# Altium schematic sheet K210_WiFi.SchDoc(K210_WiFi)
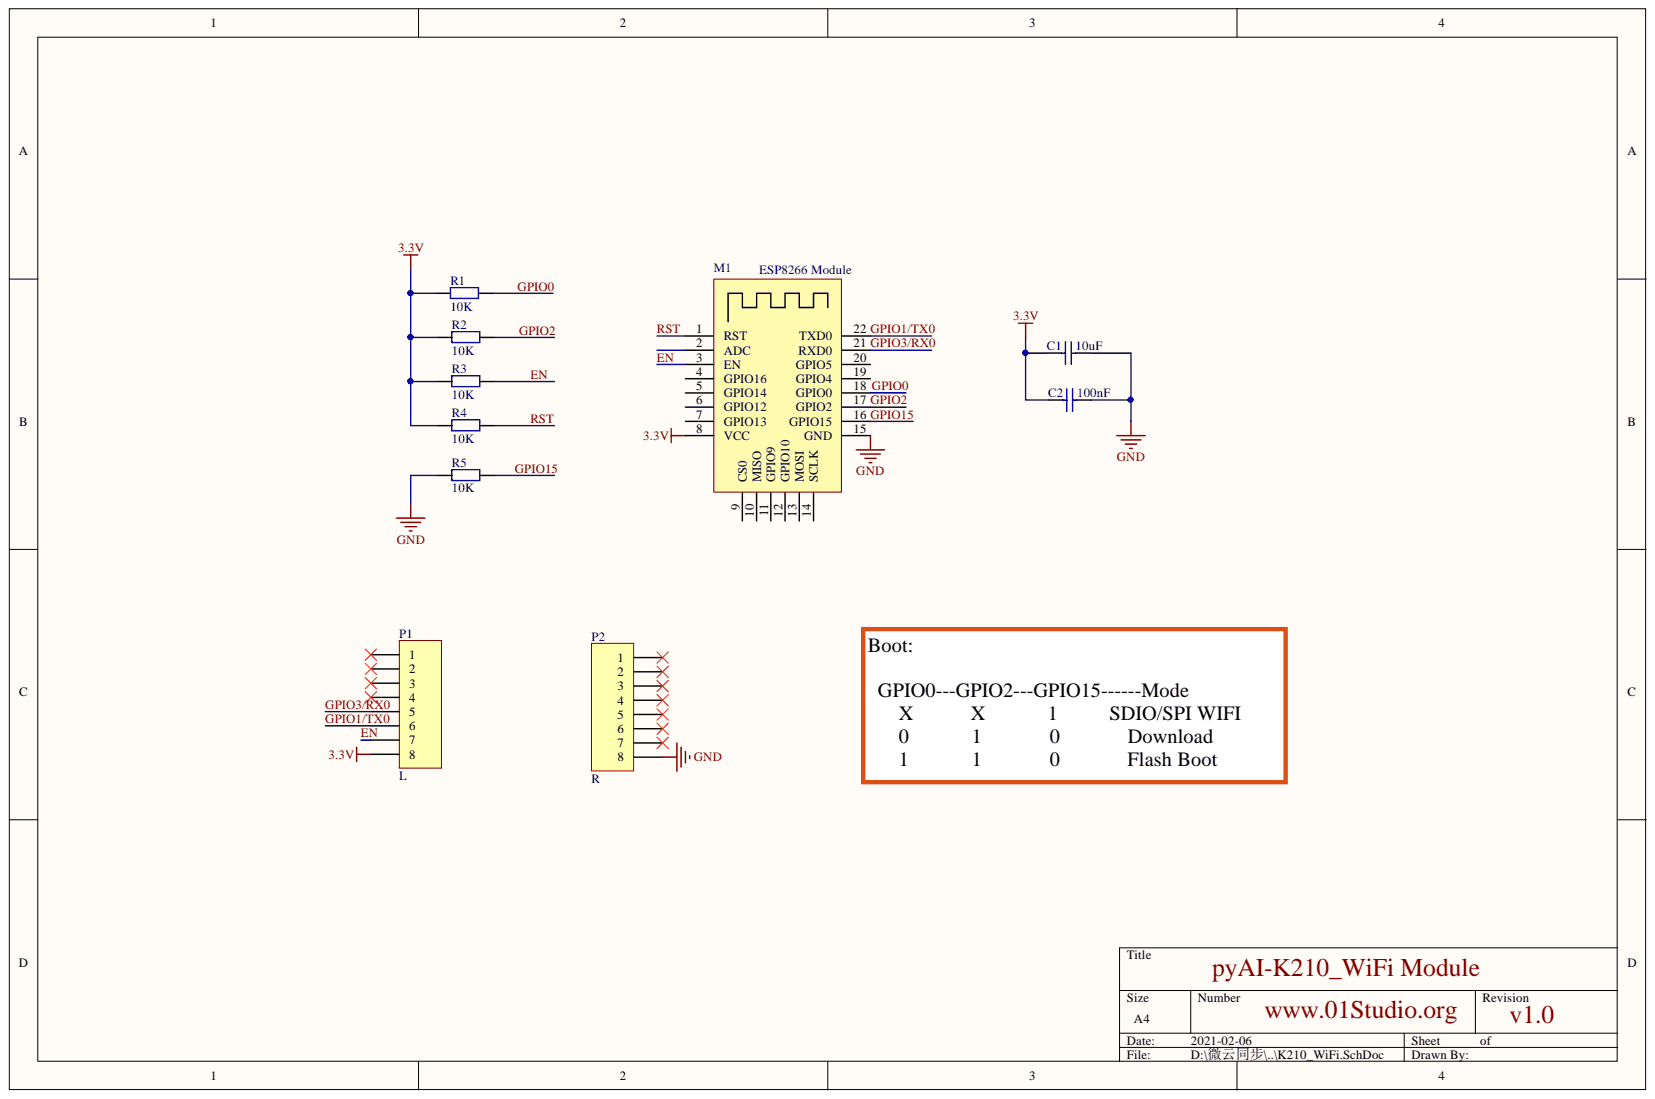
[diagram p0: K210_WiFi.SchDoc]

## Parts
C1  value 10uF  [C0603]
C2  value 100nF  [C0603]
M1  ESP8266 Module  [ESP-12F]
P1  L  [HDR1X8]
P2  R  [HDR1X8]
R1  value 10K  [R0603]
R2  value 10K  [R0603]
R3  value 10K  [R0603]
R4  value 10K  [R0603]
R5  value 10K  [R0603]

## Nets
3.3V: C1.2 C2.2 M1.8(VCC) P1.8 R1.1 R2.1 R3.1 R4.1
GND: C1.1 C2.1 M1.15(GND) P2.8 R5.1
EN: M1.3(EN) P1.7 R3.2
GPIO0: M1.18(GPIO0) R1.2
GPIO1/TX0: M1.22(TXD0) P1.6
GPIO2: M1.17(GPIO2) R2.2
GPIO3/RX0: M1.21(RXD0) P1.5
GPIO15: M1.16(GPIO15) R5.2
RST: M1.1(RST) R4.2

Not connected: M1 2(ADC) 4(GPIO16) 5(GPIO14) 6(GPIO12) 7(GPIO13) 9(CS0) 10(MISO) 11(GPIO9) 12(GPIO10) 13(MOSI) 14(SCLK) 19(GPIO4) 20(GPIO5); P1 1 2 3 4; P2 1 2 3 4 5 6 7

## Library data of the parts
C1 C2 = Cap: Capacitor; Simulation: CAP; Signal Integrity: Cap; Published: 8-Jun-2000; PackageReference: RAD-0.3; Library Name: Miscellaneous Devices.IntLib; LatestRevisionNote: Re-released for DXP Platform.; LatestRevisionDate: 17-Jul-2002; Component Kind: Standard; Publisher: Altium Limited
M1 = ESP-12F: Component Kind: Standard
P1 P2 = Header 8: Header, 8-Pin; Signal Integrity: Connector; Library Name: Miscellaneous Connectors.IntLib; LatestRevisionNote: Re-released for DXP Platform.; LatestRevisionDate: 17-Jul-2002; Component Kind: Standard; Publisher: Altium Limited
R1-R5 = Res2: Resistor; Simulation: RESISTOR; Signal Integrity: Res; Published: 8-Jun-2000; PackageReference: AXIAL-0.4; Library Name: Miscellaneous Devices.IntLib; LatestRevisionNote: Re-released for DXP Platform.; LatestRevisionDate: 17-Jul-2002; Component Kind: Standard; Publisher: Altium Limited
